# Altium schematic sheet DSub_Analog_Input.SchDoc(DSub_Input)
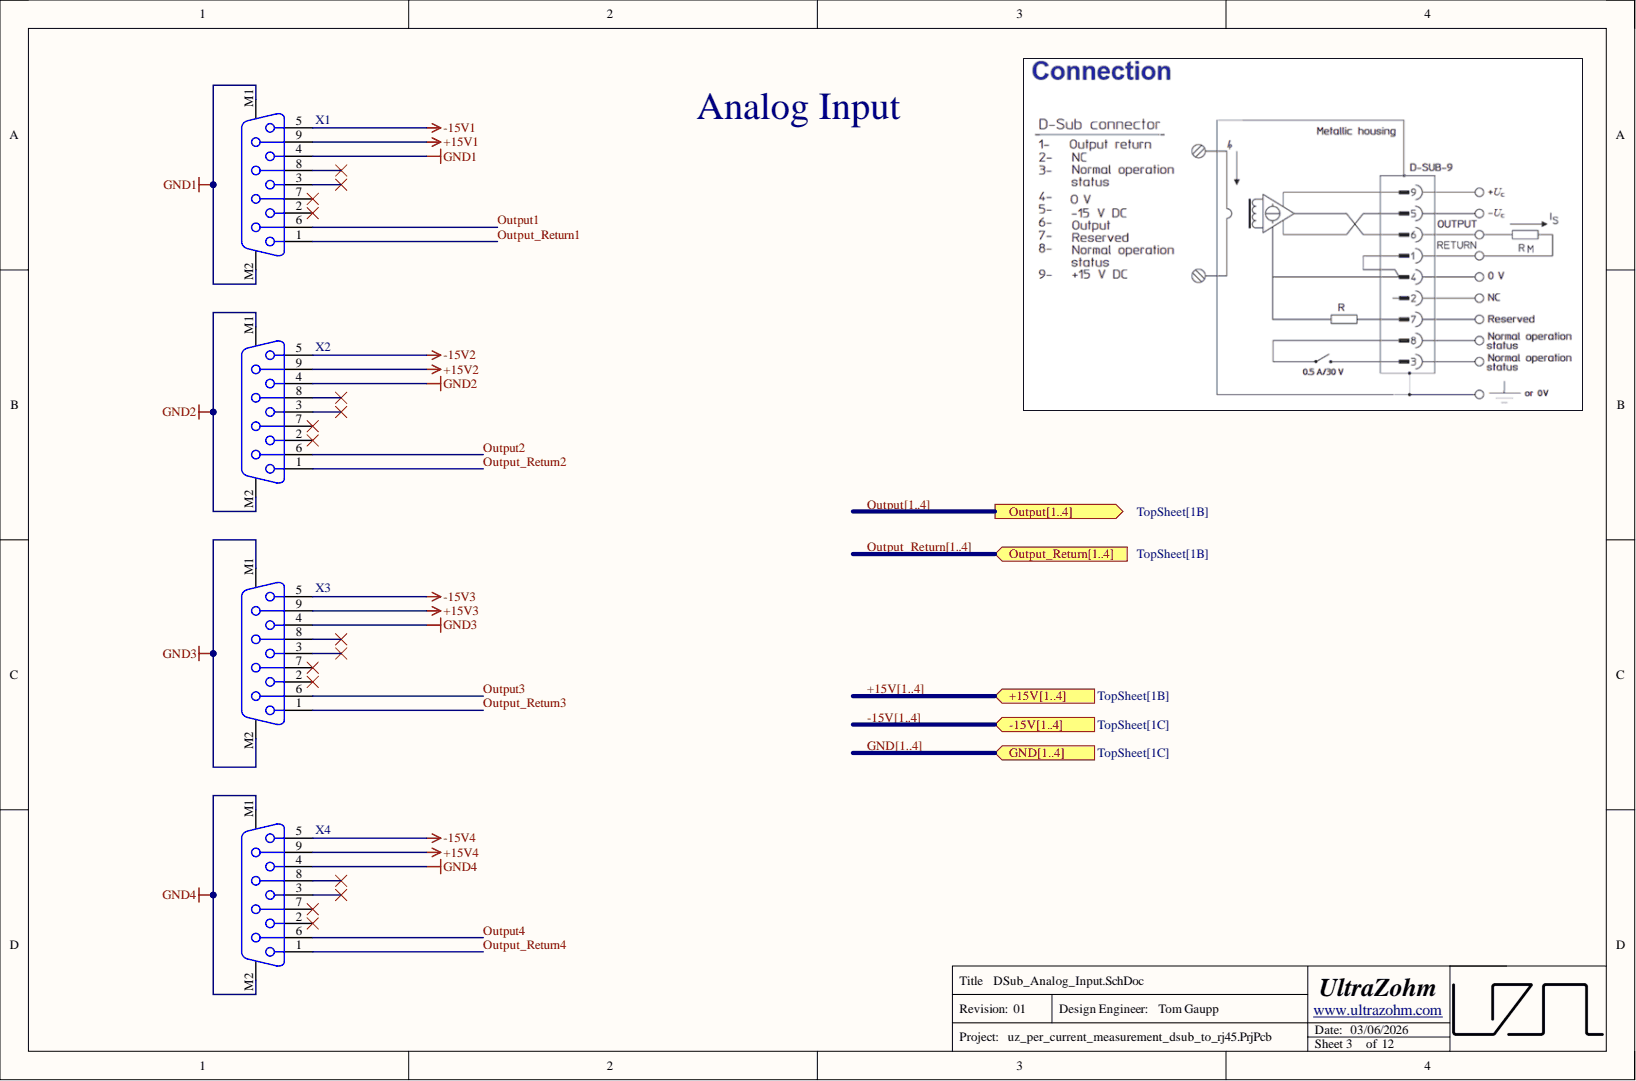
[diagram p0: DSub_Analog_Input.SchDoc]

## Parts
X1  D-SUB 9, female  [618009231121]  (value not drawn)
X2  D-SUB 9, female  [618009231121]  (value not drawn)
X3  D-SUB 9, female  [618009231121]  (value not drawn)
X4  D-SUB 9, female  [618009231121]  (value not drawn)

## Ports
+15V1, +15V2, +15V3, +15V4, +15V[1..4], -15V1, -15V2, -15V3, -15V4, -15V[1..4], GND1, GND2, GND3, GND4, GND[1..4], Output1, Output2, Output3, Output4, Output[1..4], Output_Return1, Output_Return2, Output_Return3, Output_Return4, Output_Return[1..4]

## Nets
+15V1: X1.9
+15V2: X2.9
+15V3: X3.9
+15V4: X4.9
-15V1: X1.5
-15V2: X2.5
-15V3: X3.5
-15V4: X4.5
GND1: X1.4 X1.M1 X1.M2 ; port GND[1..4]
GND2: X2.4 X2.M1 X2.M2 ; port GND[1..4]
GND3: X3.4 X3.M1 X3.M2 ; port GND[1..4]
GND4: X4.4 X4.M1 X4.M2 ; port GND[1..4]
Output1: X1.6 ; port Output[1..4]  [also labelled Output]
Output2: X2.6 ; port Output[1..4]  [also labelled Output]
Output3: X3.6 ; port Output[1..4]  [also labelled Output]
Output4: X4.6 ; port Output[1..4]  [also labelled Output]
Output_Return1: X1.1 ; port Output_Return[1..4]  [also labelled Output_Return]
Output_Return2: X2.1 ; port Output_Return[1..4]  [also labelled Output_Return]
Output_Return3: X3.1 ; port Output_Return[1..4]  [also labelled Output_Return]
Output_Return4: X4.1 ; port Output_Return[1..4]  [also labelled Output_Return]
NetX1_2: X1.2
NetX1_3: X1.3
NetX1_7: X1.7
NetX1_8: X1.8
NetX2_2: X2.2
NetX2_3: X2.3
NetX2_7: X2.7
NetX2_8: X2.8
NetX3_2: X3.2
NetX3_3: X3.3
NetX3_7: X3.7
NetX3_8: X3.8
NetX4_2: X4.2
NetX4_3: X4.3
NetX4_7: X4.7
NetX4_8: X4.8
+15V1:
+15V2:
+15V3:
+15V4:
-15V1:
-15V2:
-15V3:
-15V4:
GND1: ; port GND[1..4]
GND2: ; port GND[1..4]
GND3: ; port GND[1..4]
GND4: ; port GND[1..4]

## Library data of the parts
X1-X4 = 618009231121: D-Sub Standard Connectors WR-DSUB Female PCB 9Pin Hex Scrw 8.08mm; Manufacturer: Wuerth Elektronik; Contact Resistance: 30mOhm; Created from: AGeiger; Rated Current: 3A; Withstanding Voltage: 1000VAC; Working Voltage: 125VAC; Category: Input/Output Connectors; Component Kind: Standard; ComponentLink1Description: Datasheet; ComponentLink1URL: https://www.we-online.com/components/products/datasheet/618009231121.pdf; ComponentLink2Description: Datasheet Link; ComponentLink2URL: https://www.we-online.com/redexpert/spec/618009231121?ad; Created On: 31/05/2023 13:23:16; Database Table Name: Connector; Gender: Female; Last Updated: 31/05/2023 13:23:16; LCSC Part Number: C141882; Library Name: user.DbLib; Manufacturer Part Number: 618009231121; Match Code: WR-DSUB; Mount: Through Hole; Mounting Type: THT; Number of Pins: 9; Operating Temperature: -40 °C to +85 °C; Operating Temperature Max: 105°C; Operating Temperature Min: -55°C; Orientation: Angled; Packaging: Tray; PartID: 6; Series: D-SUB Standard; Supplier 1: Mouser; Supplier Part Number 1: 710-618009231121; Value: D-SUB 9, female
